# Altium schematic sheet Sheet2.SchDoc
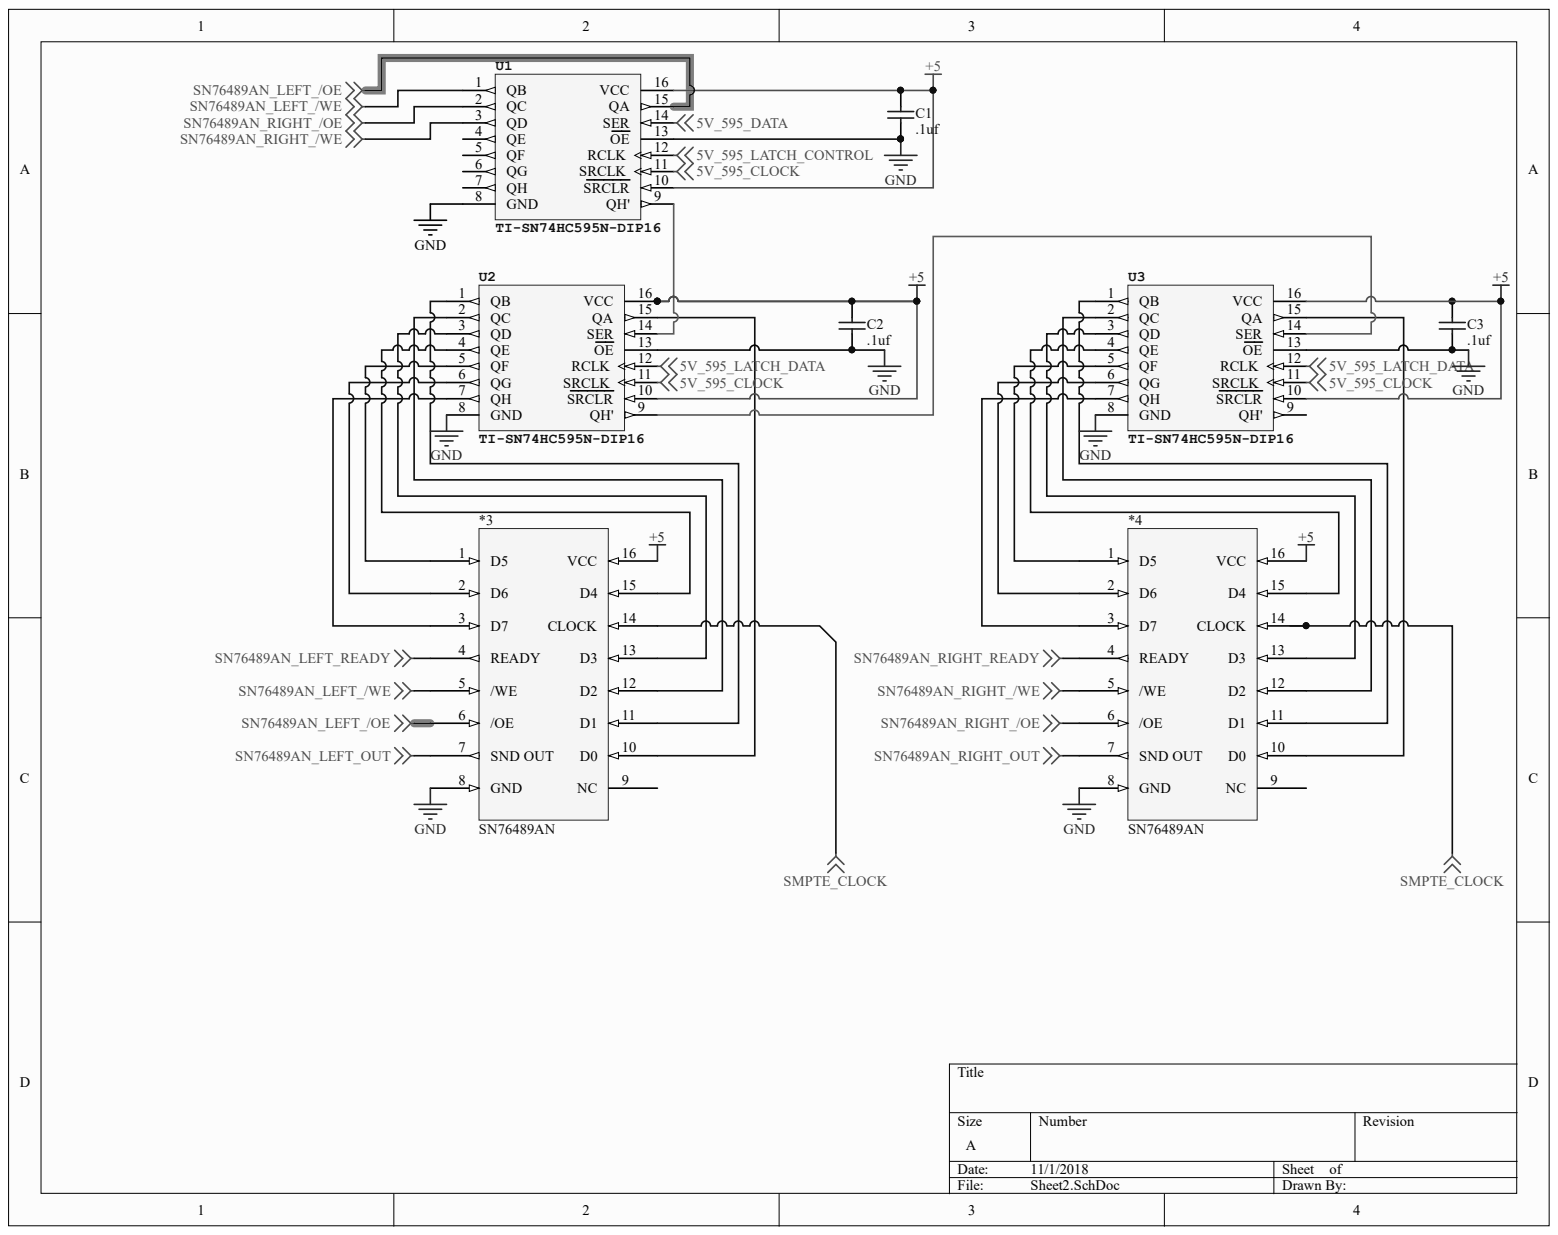
[diagram p0: Sheet2.SchDoc]

## Parts
*3  SN76489AN
*4  SN76489AN
C1  .1uf  [CAPC1005X56X25ML10T15]
C2  .1uf  [CAPC1005X56X25ML10T15]
C3  .1uf  [CAPC1005X56X25ML10T15]
U1  TI-SN74HC595N-DIP16  [PCB-7hxnpdq8j56uym734tt4-1]
U2  TI-SN74HC595N-DIP16  [PCB-7hxnpdq8j56uym734tt4-1]
U3  TI-SN74HC595N-DIP16  [PCB-7hxnpdq8j56uym734tt4-1]

## Nets
5V_595_CLOCK: U1.11(SRCLK) U2.11(SRCLK) U3.11(SRCLK)
5V_595_DATA: U1.14(SER)
5V_595_LATCH_CONTROL: U1.12(RCLK)
5V_595_LATCH_DATA: U2.12(RCLK) U3.12(RCLK)
+5: *3.16(VCC) *4.16(VCC) C1.2 C2.2 C3.2 U1.10(SRCLR) U1.16(VCC) U2.10(SRCLR) U2.16(VCC) U3.10(SRCLR) U3.16(VCC)
GND: *3.8(GND) *4.8(GND) C1.1 C2.1 C3.1 U1.8(GND) U1.13(OE) U2.8(GND) U2.13(OE) U3.8(GND) U3.13(OE)
SMPTE_CLOCK: *3.14(CLOCK) *4.14(CLOCK)
SN76489AN_LEFT_/OE: *3.6(/OE) U1.15(QA)
SN76489AN_LEFT_/WE: *3.5(/WE) U1.1(QB)
SN76489AN_LEFT_OUT: *3.7(SND_OUT)
SN76489AN_LEFT_READY: *3.4(READY)
SN76489AN_RIGHT_/OE: *4.6(/OE) U1.2(QC)
SN76489AN_RIGHT_/WE: *4.5(/WE) U1.3(QD)
SN76489AN_RIGHT_OUT: *4.7(SND_OUT)
SN76489AN_RIGHT_READY: *4.4(READY)
Net*3_1: *3.1(D5) U2.5(QF)
Net*3_2: *3.2(D6) U2.6(QG)
Net*3_3: *3.3(D7) U2.7(QH)
Net*3_10: *3.10(D0) U2.15(QA)
Net*3_11: *3.11(D1) U2.1(QB)
Net*3_12: *3.12(D2) U2.2(QC)
Net*3_13: *3.13(D3) U2.3(QD)
Net*3_15: *3.15(D4) U2.4(QE)
Net*4_1: *4.1(D5) U3.5(QF)
Net*4_2: *4.2(D6) U3.6(QG)
Net*4_3: *4.3(D7) U3.7(QH)
Net*4_10: *4.10(D0) U3.15(QA)
Net*4_11: *4.11(D1) U3.1(QB)
Net*4_12: *4.12(D2) U3.2(QC)
Net*4_13: *4.13(D3) U3.3(QD)
Net*4_15: *4.15(D4) U3.4(QE)
NetU1_9: U1.9(QH') U2.14(SER)
NetU2_9: U2.9(QH') U3.14(SER)

Not connected: *3 9(NC); *4 9(NC); U1 4(QE) 5(QF) 6(QG) 7(QH); U3 9(QH')

## Library data of the parts
*3 *4 = SN76489AN-1: SN76489 Digital Complex Sound Generator; CustomComponentID: fwyzdshkacgxr1g1ndga; Component Kind: Standard; Library Name: FavoritesLibrary
C1-C3 = CMP-16527024-4: Manufacturer Part Number: 0402YD104KAT2A; Capacitance: 1e-07 F; Capacitance Tolerance: ±10%; Dielectric Characteristic: X5R; Dielectric Material: Ceramic Multilayer; Lead-Free Status: Lead Free; Voltage Rating (DC): 16.0 V; Case/Package: 0402; Component Kind: Standard; Library Name: CommunityVault; Mounting Style: Surface Mount; Number of Pins: 2; OctopartID: 6877a17fb5d5b7b0; Octopart Url: https://octopart.com/0402yd104kat2a-avx+interconnect+%2F+elco-194756; REACH SVHC Compliance: No SVHC; RoHS: Compliant; Size-Height: 0.00056 m; Size-Length: 0.001 m; Size-Width: 0.0005 m
U1-U3 = SN74HC595N: Shift Register Single 8 Bit Serial To Serial Parallel 16 Pin Plastic DIP Tube; Lead-Free Status: Lead Free; Output Current Drive: -1.00 mA; Voltage Nodes: 6.00 V, 5.00 V, 2.00 V; Case/Package: PDIP; Component Kind: Standard; Library Name: Octopart library; Lifecycle Status: Active; Number of Pins: 16; OctopartID: 168fdf857ed2c948; Octopart URL: http://octopart.com/sn74hc595n-texas+instruments-19771
